# Altium schematic sheet Robot-Power V3.0.SchDoc("Robot-Power V3.0")
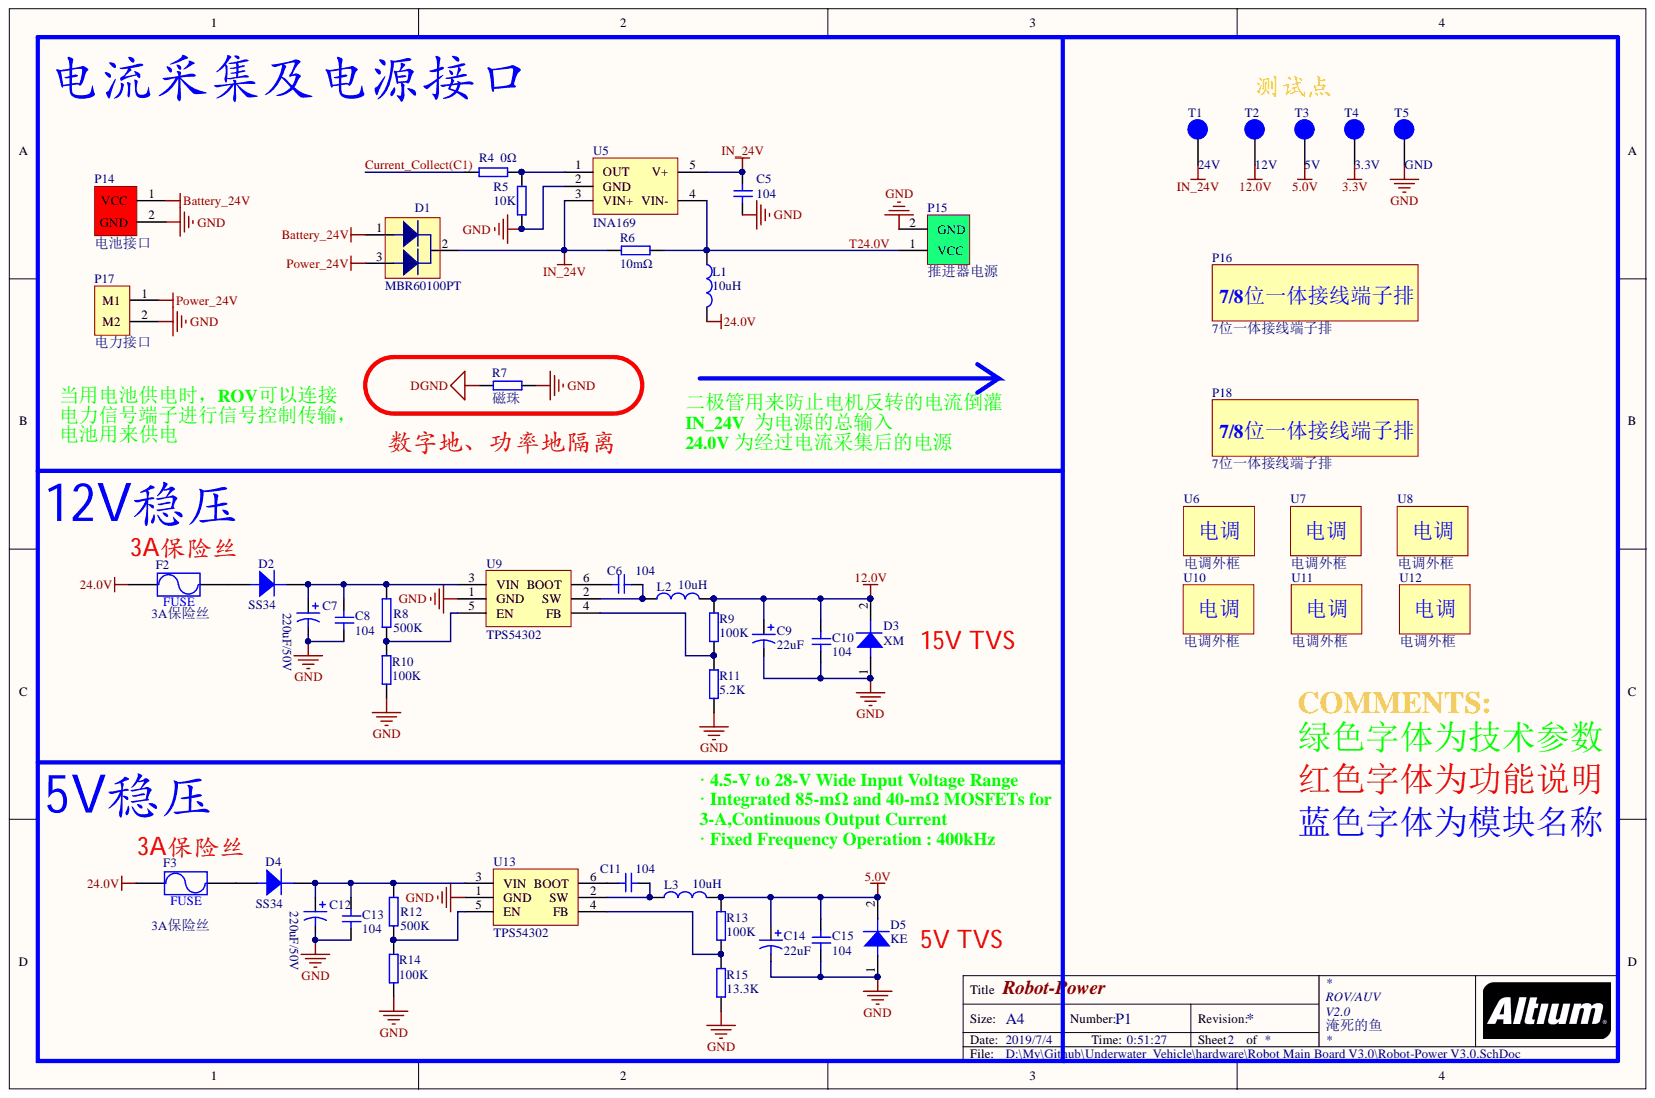
[diagram p0: Robot-Power V3.0.SchDoc]

## Parts
C5  104  [0805C]
C6  104  [0805C]
C7  220uF/50V  [RB.1.2]
C8  104  [0805C]
C9  22uF  [TAJ-C]
C10  104  [0805C]
C11  104  [0805C]
C12  220uF/50V  [RB.1.2]
C13  104  [0805C]
C14  22uF  [TAJ-C]
C15  104  [0805C]
D1  MBR60100PT  [TO-247LS]
D2  SS34  [SMA/DO-214AC]
D3  XM  [SMBJ]
D4  SS34  [SMA/DO-214AC]
D5  KE  [SMBJ]
F2  3A保险丝  [FUSE-1206]
F3  3A保险丝  [FUSE-1206]
L1  10uH  [CDRH124]
L2  10uH  [CDRH124]
L3  10uH  [CDRH124]
P14  电池接口  [XT60-F]
P15  推进器电源  [XT60-F]
P16  7位一体接线端子排  [7/8一体接线端子]
P17  电力接口  [XT60-F]
P18  7位一体接线端子排  [7/8一体接线端子]
R4  0Ω  [0805R]
R5  10K  [0805R]
R6  10mΩ  [2512R]
R7  磁珠  [1210R]
R8  500K  [0805R]
R9  100K  [0805R]
R10  100K  [0805R]
R11  5.2K  [0805R]
R12  500K  [0805R]
R13  100K  [0805R]
R14  100K  [0805R]
R15  13.3K  [0805R]
T1  24V  [HEADER 1]
T2  12V  [HEADER 1]
T3  5V  [HEADER 1]
T4  3.3V  [HEADER 1]
T5  GND  [HEADER 1]
U5  INA169  [SOT23-5]
U6  电调外框  [电调外框]
U7  电调外框  [电调外框]
U8  电调外框  [电调外框]
U9  TPS54302  [SOT23-6]
U10  电调外框  [电调外框]
U11  电调外框  [电调外框]
U12  电调外框  [电调外框]
U13  TPS54302  [SOT23-6]

## Nets
3.3V: T4.1
5.0V: C14.1 C15.2 D5.2 L3.2 R13.2 T3.1
12.0V: C9.1 C10.2 D3.2 L2.2 R9.2 T2.1
24.0V: F2.1 F3.1 L1.2
BATTERY_24V: D1.1 P14.1
DGND: R7.2
GND: C5.1 C7.2 C8.1 C9.2 C10.1 C12.2 C13.1 C14.2 C15.1 D3.1 D5.1 P14.2 P15.2 P17.2 R5.2 R7.1 R10.1 R11.1 R14.1 R15.1 T5.1 U5.2(GND) U9.1(GND) U13.1(GND)
IN_24V: C5.2 D1.2 R6.2 T1.1 U5.3(VIN+) U5.5(V+)
POWER_24V: D1.3 P17.1
CURRENT_COLLECT(C1): R4.1
T24.0V: L1.1 P15.1 R6.1 U5.4(VIN-)
NetC6_1: C6.1 L2.1 U9.2(SW)
NetC6_2: C6.2 U9.6(BOOT)
NetC7_1: C7.1 C8.2 D2.2 R8.2 U9.3(VIN)
NetC11_1: C11.1 L3.1 U13.2(SW)
NetC11_2: C11.2 U13.6(BOOT)
NetC12_1: C12.1 C13.2 D4.2 R12.2 U13.3(VIN)
NetD2_1: D2.1 F2.2
NetD4_1: D4.1 F3.2
NetR4_2: R4.2 R5.1 U5.1(OUT)
NetR8_1: R8.1 R10.2 U9.5(EN)
NetR9_1: R9.1 R11.2 U9.4(FB)
NetR12_1: R12.1 R14.2 U13.5(EN)
NetR13_1: R13.1 R15.2 U13.4(FB)

## Library data of the parts
C5 C6 C8 C10 C11 C13 C15 = Cap1: 无极贴片电容; Component Kind: Standard; Library Name: 集大电协1.5.SchLib
C7 C12 = Cap3: 直插极性电容; Component Kind: Standard; Library Name: 集大电协1.5.SchLib
C9 C14 = Pol Cap: 极性贴片电容; Component Kind: Standard; Library Name: 集大电协1.5.SchLib
D2-D5 = Diode: 二极管; Component Kind: Standard; Library Name: 集大电协1.5.SchLib
F2 F3 = Fuse: 保险丝; Component Kind: Standard; Library Name: 集大电协1.2.SchLib
L1-L3 = L: 电感; Component Kind: Standard; Library Name: 集大电协1.5.SchLib
P14 = 电源端子: Component Kind: Standard; Library Name: 集大电协1.5.SchLib
P17 = 接线端子-2PIN: 2PIN; Component Kind: Standard; Library Name: 集大电协1.5.SchLib
R4-R15 = Res: 电阻; Component Kind: Standard
  R4-R6 R8-R15: Library Name: 集大电协1.5.SchLib
  R7: Library Name: 集大电协1.2.SchLib
T1-T5 = 测试点: Component Kind: Standard; Description: 测试点; Library Name: 集大电协1.2.SchLib
U6-U8 U10-U12 = 电调: Component Kind: Standard; Library Name: 集大电协1.5.SchLib
D1 = MBR60100PT: Component Kind: Standard; Library Name: 集大电协1.5.SchLib
P15 = 推进器电源: Component Kind: Standard; Library Name: 集大电协1.5.SchLib
P16 P18 = 7位一体接线端子排: Component Kind: Standard; Library Name: 集大电协1.5.SchLib
U5 = INA169: Component Kind: Standard; Library Name: 集大电协1.5.SchLib
U9 U13 = TPS54302: Component Kind: Standard; Library Name: 集大电协1.5.SchLib
